# Altium schematic sheet Astable Multivibrator.SchDoc
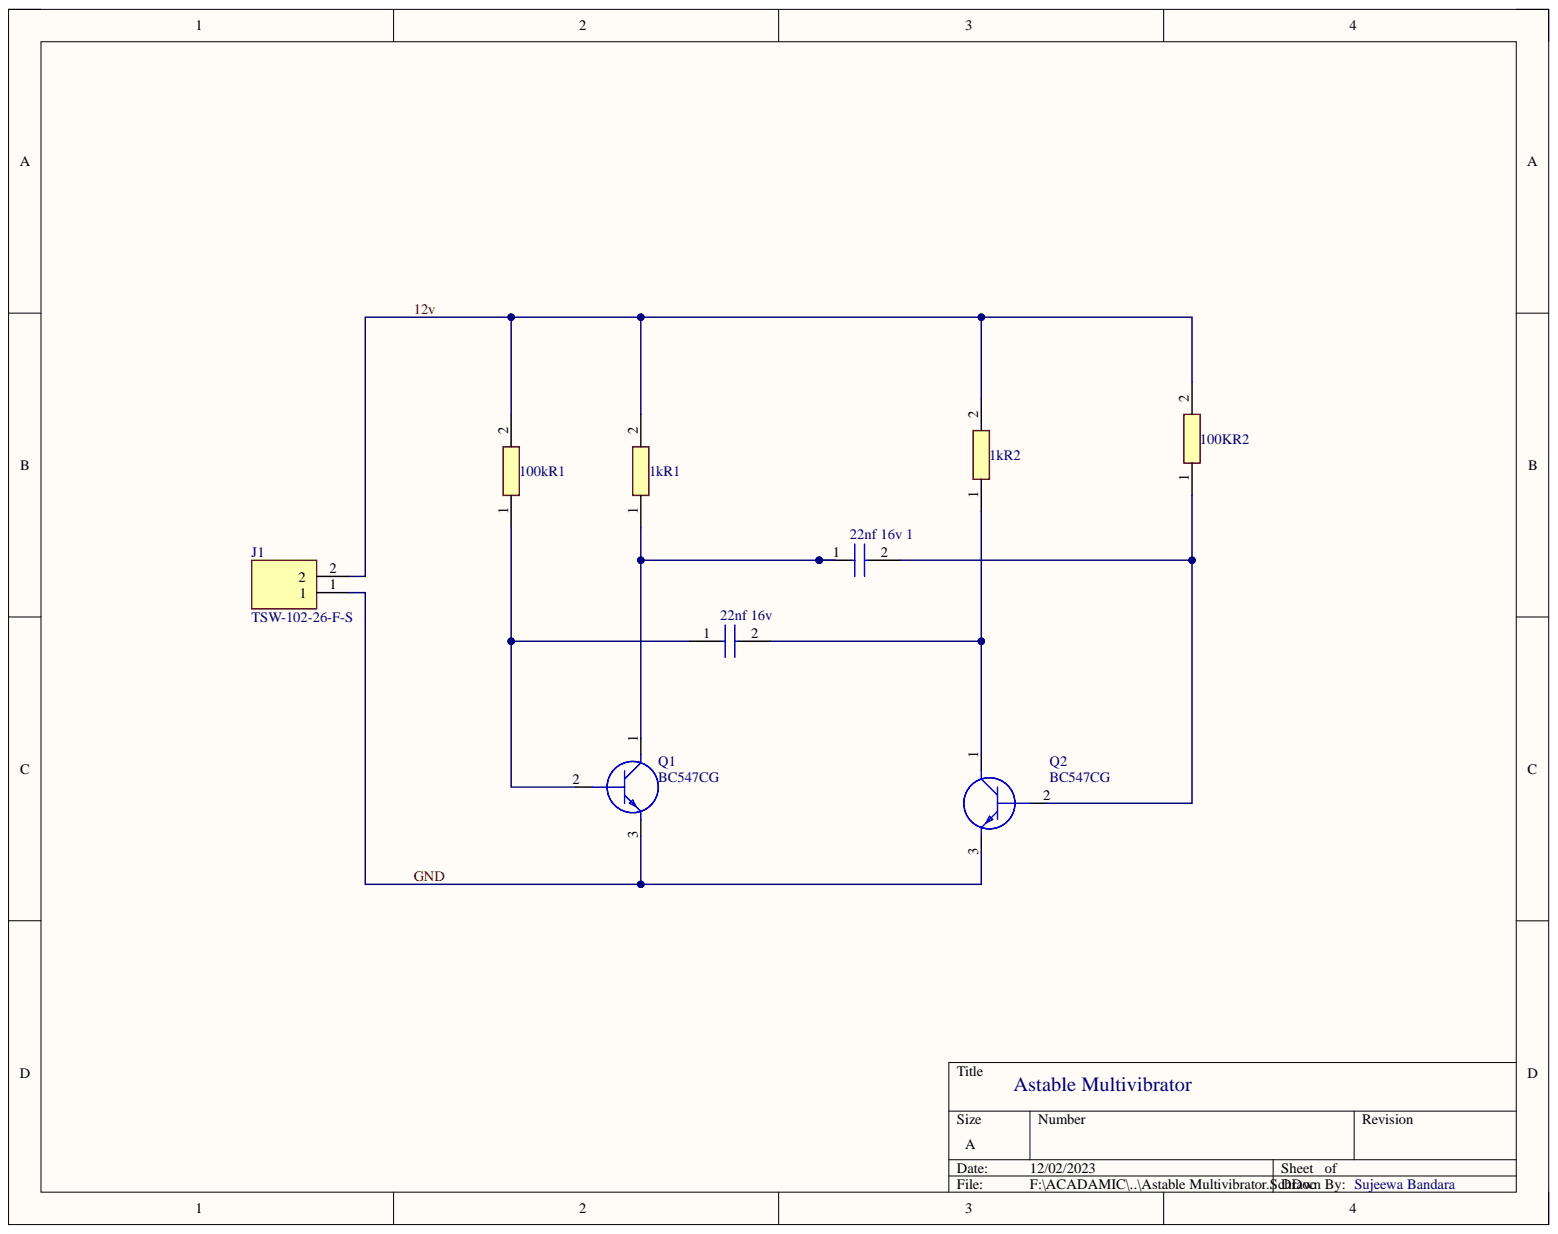
[diagram p0: Astable Multivibrator.SchDoc]

## Parts
1kR1  CPF0805B10K5E1  [RESC2012X65N]  (value not drawn)
1kR2  CPF0805B10K5E1  [RESC2012X65N]  (value not drawn)
22nf_16v  0603YC223KAT2A  [CAPC1608X90N]  (value not drawn)
22nf_16v_1  0603YC223KAT2A  [CAPC1608X90N]  (value not drawn)
100kR1  CRCW0805100KFKEAHP  [RESC2012X60N]  (value not drawn)
100KR2  CRCW0805100KFKEAHP  [RESC2012X60N]  (value not drawn)
J1  TSW-102-26-F-S  [TSW-102-XX-YY-S]
Q1  BC547CG  [TO-92_(TO-226)]
Q2  BC547CG  [TO-92_(TO-226)]

## Nets
12v: 1kR1.2 1kR2.2 100kR1.2 100KR2.2 J1.2
GND: J1.1 Q1.3 Q2.3
Net1kR1_1: 1kR1.1 22nf_16v_1.1 Q1.1
Net1kR2_1: 1kR2.1 22nf_16v.2 Q2.1
Net22nf 16v 1_2: 22nf_16v_1.2 100KR2.1 Q2.2
Net22nf 16v_1: 22nf_16v.1 100kR1.1 Q1.2

## Library data of the parts
1kR1 1kR2 = CPF0805B10K5E1: Resistor; Manufacturer_Name: TE Connectivity; Component Kind: Standard; Datasheet Link: http://docs-europe.electrocomponents.com/webdocs/13d1/0900766b813d116f.pdf; Height: 0.65mm; Manufacturer_Part_Number: CPF0805B10K5E1; Mouser Part Number: 279-CPF0805B10K5E1; Mouser Price/Stock: https://www.mouser.com/Search/Refine.aspx?Keyword=279-CPF0805B10K5E1
22nf_16v 22nf_16v_1 = 0603YC223KAT2A: Capacitor; Manufacturer_Name: AVX; Arrow Part Number: 0603YC223KAT2A; Arrow Price/Stock: https://www.arrow.com/en/products/0603yc223kat2a/avx; Component Kind: Standard; Datasheet Link: https://componentsearchengine.com/Datasheets/1/06031A100FAT2A.pdf; Height: 0.9mm; Manufacturer_Part_Number: 0603YC223KAT2A; Mouser Part Number: 581-0603YC223K; Mouser Price/Stock: https://www.mouser.co.uk/ProductDetail/AVX/0603YC223KAT2A?qs=394Pi71zH6hTiH4tlhTRbQ%3D%3D
100kR1 100KR2 = CRCW0805100KFKEAHP: Resistor; Manufacturer_Name: Vishay; Arrow Part Number: CRCW0805100KFKEAHP; Arrow Price/Stock: https://www.arrow.com/en/products/crcw0805100kfkeahp/vishay?region=nac; Component Kind: Standard; Datasheet Link: https://componentsearchengine.com/Datasheets/1/CRCW08051R00FKEAHP.pdf; Height: 0.6mm; Manufacturer_Part_Number: CRCW0805100KFKEAHP; Mouser Part Number: 71-CRCW0805100KFKEAH; Mouser Price/Stock: https://www.mouser.co.uk/ProductDetail/Vishay-Dale/CRCW0805100KFKEAHP?qs=k2%2FDWSARqgFvzezk%252B9pwaw%3D%3D
J1 = TSW-102-26-F-S: Connector; Manufacturer_Name: SAMTEC; Arrow Part Number: TSW-102-26-F-S; Arrow Price/Stock: https://www.arrow.com/en/products/tsw-102-26-f-s/samtec?region=nac; Component Kind: Standard; Datasheet Link: http://suddendocs.samtec.com/prints/tsw-xxx-xx-xxx-x-xx-xxx-mkt.pdf; Manufacturer_Part_Number: TSW-102-26-F-S; Mouser Part Number: 200-TSW10226FS; Mouser Price/Stock: https://www.mouser.co.uk/ProductDetail/Samtec/TSW-102-26-F-S?qs=rU5fayqh%252BE12Ytn94yQuRg%3D%3D
Q1 Q2 = BC547CG: Transistor BJT NPN; Manufacturer_Name: onsemi; Arrow Part Number: BC547CG; Arrow Price/Stock: https://www.arrow.com/en/products/bc547cg/on-semiconductor?region=nac; Component Kind: Standard; Datasheet Link: https://www.onsemi.com/pub/Collateral/BC546-D.PDF; Height: 5.33mm; Manufacturer_Part_Number: BC547CG; Mouser Part Number: 863-BC547CG; Mouser Price/Stock: https://www.mouser.co.uk/ProductDetail/onsemi/BC547CG?qs=vLkC5FC1VN%252BHx9C8w0tCew%3D%3D
